# Altium schematic sheet SENSE.SchDoc
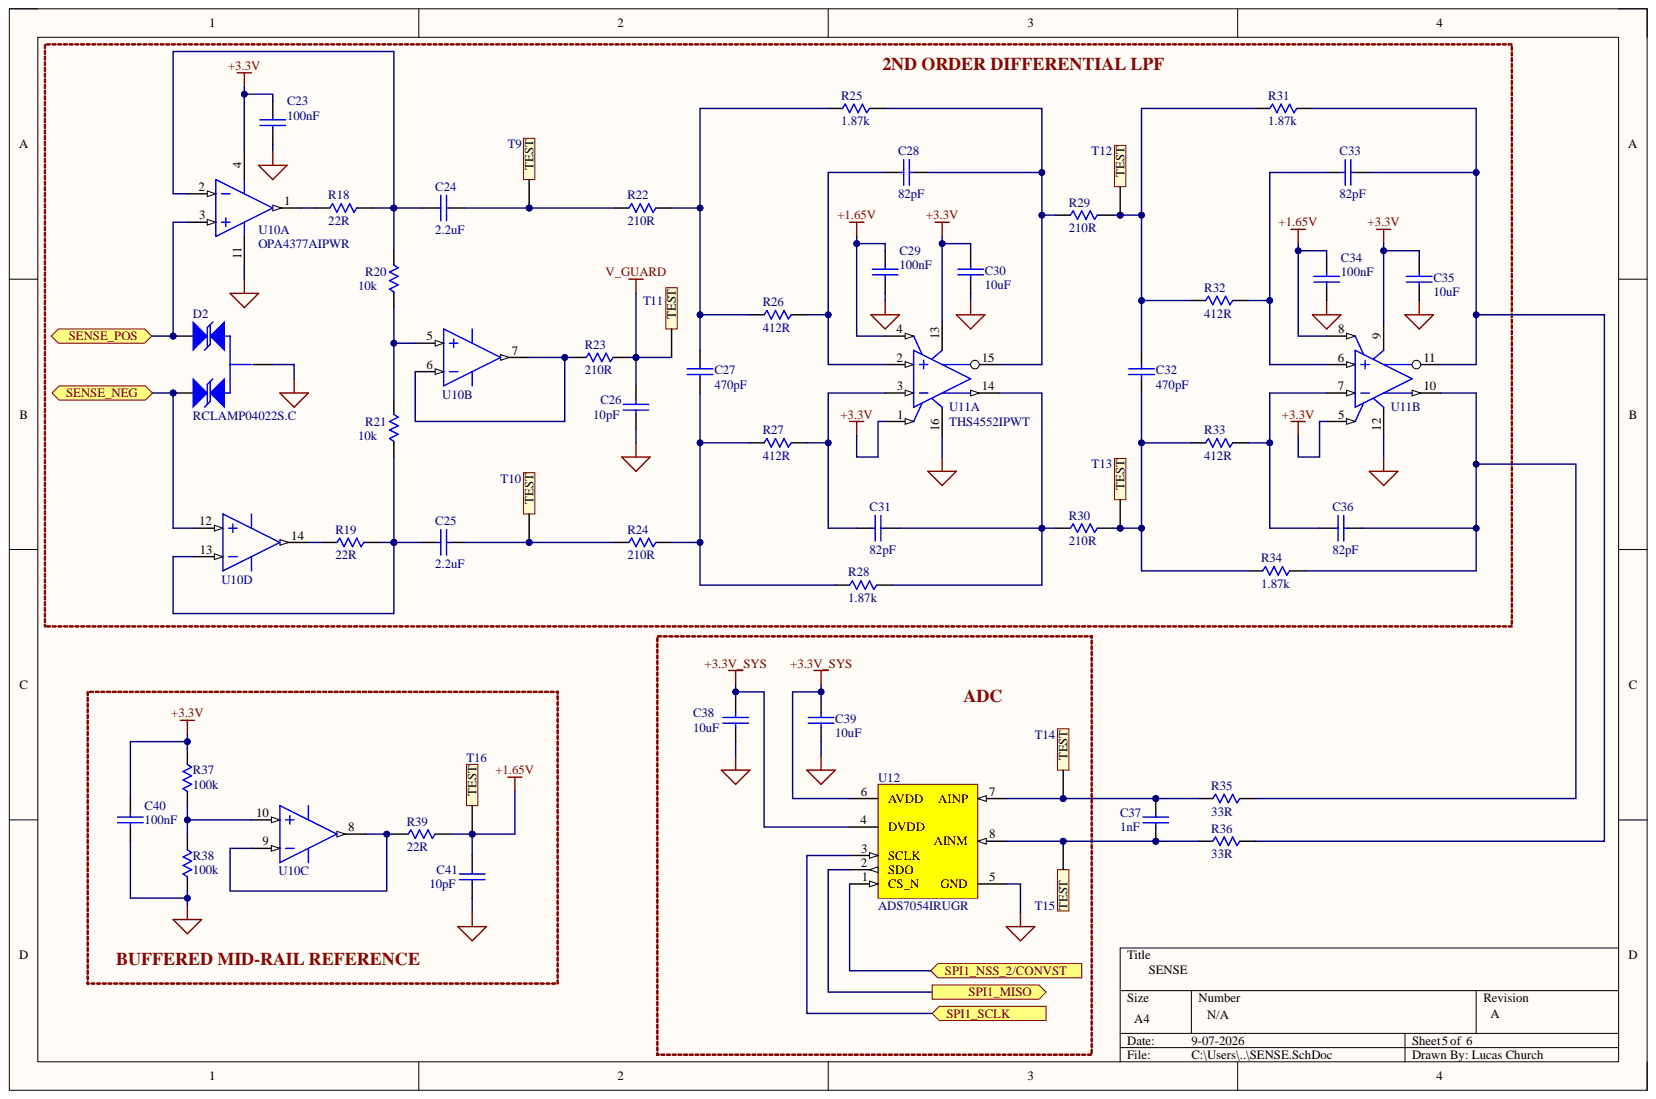
[diagram p0: SENSE.SchDoc]

## Parts
C23  100nF  [0603-CAP]
C24  2.2uF  [0603-CAP]
C25  2.2uF  [0603-CAP]
C26  10pF  [0603-CAP]
C27  470pF  [0603-CAP]
C28  82pF  [0603-CAP]
C29  100nF  [0603-CAP]
C30  10uF  [0603-CAP]
C31  82pF  [0603-CAP]
C32  470pF  [0603-CAP]
C33  82pF  [0603-CAP]
C34  100nF  [0603-CAP]
C35  10uF  [0603-CAP]
C36  82pF  [0603-CAP]
C37  1nF  [0603-CAP]
C38  10uF  [0603-CAP]
C39  10uF  [0603-CAP]
C40  100nF  [0603-CAP]
C41  10pF  [0603-CAP]
D2  RCLAMP04022S.C  [SOT-23 3L]
R18  22R  [0603-RES]
R19  22R  [0603-RES]
R20  10k  [0603-RES]
R21  10k  [0603-RES]
R22  210R  [0603-RES]
R23  210R  [0603-RES]
R24  210R  [0603-RES]
R25  1.87k  [0603-RES]
R26  412R  [0603-RES]
R27  412R  [0603-RES]
R28  1.87k  [0603-RES]
R29  210R  [0603-RES]
R30  210R  [0603-RES]
R31  1.87k  [0603-RES]
R32  412R  [0603-RES]
R33  412R  [0603-RES]
R34  1.87k  [0603-RES]
R35  33R  [0603-RES]
R36  33R  [0603-RES]
R37  100k  [0603-RES]
R38  100k  [0603-RES]
R39  22R  [0603-RES]
T9  5002K  [TESTPOINT White]  (value not drawn)
T10  5002K  [TESTPOINT White]  (value not drawn)
T11  5002K  [TESTPOINT White]  (value not drawn)
T12  5002K  [TESTPOINT White]  (value not drawn)
T13  5002K  [TESTPOINT White]  (value not drawn)
T14  5002K  [TESTPOINT White]  (value not drawn)
T15  5002K  [TESTPOINT White]  (value not drawn)
T16  5002K  [TESTPOINT White]  (value not drawn)
U10  OPA4377AIPWR  [PW0014A_N]  (parts A,B,C,D)
U11  THS4552IPWT  [PW0016A_N]  (parts A,B)
U12  ADS7054IRUGR  [8-X2QFN (1.5x1.5)]

## Ports
SENSE_NEG, SENSE_POS, SPI1_MISO, SPI1_NSS_2/CONVST, SPI1_SCLK

## Nets
+1.65V: C29.1 C34.1 C41.1 R39.2 T16.1 U11.4 U11.8
+3.3V: C23.1 C30.1 C35.1 C40.1 R37.2 U10.4 U11.1 U11.5 U11.9 U11.13
+3.3V_SYS: C38.1 C39.1 U12.4(DVDD) U12.6(AVDD)
GND: C23.2 C26.2 C29.2 C30.2 C34.2 C35.2 C38.2 C39.2 C40.2 C41.2 D2.3 R38.1 U10.11 U11.12 U11.16 U12.5(GND)
V_GUARD: C26.1 R23.2 T11.1
SENSE_NEG: D2.2 U10.12 ; port SENSE_NEG
SENSE_POS: D2.1 U10.3 ; port SENSE_POS
SPI1_MISO: U12.2(SDO) ; port SPI1_MISO
SPI1_NSS_2/CONVST: U12.1(CS_N) ; port SPI1_NSS_2/CONVST
SPI1_SCLK: U12.3(SCLK) ; port SPI1_SCLK
NetC24_1: C24.1 R22.1 T9.1
NetC24_2: C24.2 R18.2 R20.1 U10.2
NetC25_1: C25.1 R24.1 T10.1
NetC25_2: C25.2 R19.2 R21.2 U10.13
NetC27_1: C27.1 R24.2 R27.1 R28.1
NetC27_2: C27.2 R22.2 R25.1 R26.1
NetC28_1: C28.1 R26.2 U11.2
NetC28_2: C28.2 R25.2 R29.1 U11.15
NetC31_1: C31.1 R27.2 U11.3
NetC31_2: C31.2 R28.2 R30.1 U11.14
NetC32_1: C32.1 R30.2 R33.1 R34.1 T13.1
NetC32_2: C32.2 R29.2 R31.1 R32.1 T12.1
NetC33_1: C33.1 R32.2 U11.6
NetC33_2: C33.2 R31.2 R36.2 U11.11
NetC36_1: C36.1 R33.2 U11.7
NetC36_2: C36.2 R34.2 R35.2 U11.10
NetC37_1: C37.1 R35.1 T14.1 U12.7(AINP)
NetC37_2: C37.2 R36.1 T15.1 U12.8(AINM)
NetR18_1: R18.1 U10.1
NetR19_1: R19.1 U10.14
NetR20_2: R20.2 R21.1 U10.5
NetR23_1: R23.1 U10.6 U10.7
NetR37_1: R37.1 R38.2 U10.10
NetR39_1: R39.1 U10.8 U10.9

## Library data of the parts
C23 C29 C34 C40 = CGA3E2C0G1H472J080AA: CAP CER 100 NF 50V X7R 0603; Manufacturer 1: YAGEO; Manufacturer Part Number 1: CC0603KRX7R9BB104; Database Table Name: Ceramic 0603$; Tech#: SMT; Component Kind: Standard; Detailed Description: CAP CER 100 NF 50V X7R 0603; Price(100): 0.1434; Price(250): 0.10756; Supplier 1: DigiKey; Supplier 2: Mouser; Supplier Part Number 1: 311-1344-1-ND; Supplier Part Number 2: 810-CGA3E2C0G1H472J; Library Name: SGL_Capacitors.DBLib
C24 C25 = CGA3E2C0G1H472J080AA: CAP CER 2.2UF 35V X5R 0603; Manufacturer 1: Murata Electronics; Manufacturer Part Number 1: GRM188R6YA225MA12D; Database Table Name: Ceramic 0603$; Tech#: SMT; Component Kind: Standard; Detailed Description: CAP CER 2.2UF 35V X5R 0603; Price(100): 0.1434; Price(250): 0.10756; Supplier 1: DigiKey; Supplier 2: Mouser; Supplier Part Number 1: 490-10486-1-ND; Supplier Part Number 2: 810-CGA3E2C0G1H472J; Library Name: SGL_Capacitors.DBLib
C26 C41 = CGA3E2C0G1H472J080AA: CAP CER 10PF 50V C0G/NPO 0603; Manufacturer 1: YAGEO; Manufacturer Part Number 1: CC0603JRNPO9BN100; Database Table Name: Ceramic 0603$; Tech#: SMT; Component Kind: Standard; Detailed Description: CAP CER 10PF 50V C0G/NPO 0603; Price(100): 0.1434; Price(250): 0.10756; Supplier 1: DigiKey; Supplier 2: Mouser; Supplier Part Number 1: 311-1058-1-ND; Supplier Part Number 2: 810-CGA3E2C0G1H472J; Library Name: SGL_Capacitors.DBLib
C27 C32 = CGA3E2C0G1H472J080AA: CAP CER 470PF 50V C0G 0603; Manufacturer 1: Samsung; Manufacturer Part Number 1: CL10C471JB8NNNC; Database Table Name: Ceramic 0603$; Tech#: SMT; Component Kind: Standard; Detailed Description: CAP CER 470PF 50V C0G 0603; Price(100): 0.1434; Price(250): 0.10756; Supplier 1: DigiKey; Supplier 2: Mouser; Supplier Part Number 1: 1276-1168-1-ND; Supplier Part Number 2: 810-CGA3E2C0G1H472J; Library Name: SGL_Capacitors.DBLib
C28 C31 C33 C36 = CGA3E2C0G1H472J080AA: CAP CER 82PF 50V C0G 0603; Manufacturer 1: KEMET; Manufacturer Part Number 1: C0603C820J5GACTU; Database Table Name: Ceramic 0603$; Tech#: SMT; Component Kind: Standard; Detailed Description: CAP CER 82PF 50V C0G 0603; Price(100): 0.1434; Price(250): 0.10756; Supplier 1: DigiKey; Supplier 2: Mouser; Supplier Part Number 1: 399-C0603C820J5GACTUCT-ND; Supplier Part Number 2: 810-CGA3E2C0G1H472J; Library Name: SGL_Capacitors.DBLib
C30 C35 C38 C39 = CGA3E2C0G1H472J080AA: CAP CER 10uF 6.3V X5R 0603; Manufacturer 1: Murata Electronics; Manufacturer Part Number 1: GRM188Z71A106KA73D; Database Table Name: Ceramic 0603$; Detailed Description: CAP CER 100 NF 50V X7R 0603; Tech#: SMT; Component Kind: Standard; Price(100): 0.1434; Price(250): 0.10756; Supplier 1: DigiKey; Supplier 2: Mouser; Supplier Part Number 1: 490-GRM188Z71A106KA73DCT-ND; Supplier Part Number 2: 810-CGA3E2C0G1H472J; Library Name: SGL_Capacitors.DBLib
C37 = CGA3E2C0G1H472J080AA: CAP CER 1000 PF 50V C0G 0603; Manufacturer 1: Murata; Manufacturer Part Number 1: GRM1885C1H102JA01D; Database Table Name: Ceramic 0603$; Detailed Description: CAP CER 100 NF 50V X7R 0603; Tech#: SMT; Component Kind: Standard; Price(100): 0.1434; Price(250): 0.10756; Supplier 1: DigiKey; Supplier 2: Mouser; Supplier Part Number 1: 490-1451-1-ND; Supplier Part Number 2: 810-CGA3E2C0G1H472J; Library Name: SGL_Capacitors.DBLib
R18 R19 R39 = 4.99K 0603: RES SMD 22OHM 0.1% 1/10W 0603; Manufacturer 1: YAGEO; Manufacturer Part Number 1: RT0603BRD0722RL; Database Table Name: 0603$; Tech#: SMT; Component Kind: Standard; Detailed Description: RES SMD 22OHM 0.1% 1/10W 0603; Price(100): 0.023; Simulation: Resistor; Supplier 1: DigiKey; Supplier 2: Mouser; Supplier Part Number 1: 13-RT0603BRD0722RLCT-ND; Supplier Part Number 2: 754-RR0816P-4991D68H; Library Name: SGL_Resistives.DBLib
R20 R21 = 4.99K 0603: RES SMD 10K OHM 1% 1/10W 0603; Manufacturer 1: Panasonic Industry; Manufacturer Part Number 1: ERJ-3EKF1002V; Database Table Name: 0603$; Tech#: SMT; Component Kind: Standard; Detailed Description: RES SMD 10K OHM 1% 1/10W 0603; Price(100): 0.023; Simulation: Resistor; Supplier 1: DigiKey; Supplier 2: Mouser; Supplier Part Number 1: P10.0KHCT-ND; Supplier Part Number 2: 754-RR0816P-4991D68H; Library Name: SGL_Resistives.DBLib
R22-R24 R29 R30 = 4.99K 0603: RES SMD 210OHM 0.1% 1/10W 0603; Manufacturer 1: YAGEO; Manufacturer Part Number 1: RT0603BRD07210RL; Database Table Name: 0603$; Tech#: SMT; Component Kind: Standard; Detailed Description: RES SMD 210OHM 0.1% 1/10W 0603; Price(100): 0.023; Simulation: Resistor; Supplier 1: DigiKey; Supplier 2: Mouser; Supplier Part Number 1: YAG4502CT-ND; Supplier Part Number 2: 754-RR0816P-4991D68H; Library Name: SGL_Resistives.DBLib
R25 R28 R31 R34 = 4.99K 0603: RES SMD 1.87KOHM 0.1% 1/10W 0603; Manufacturer 1: YAGEO; Manufacturer Part Number 1: RT0603BRD071K87L; Database Table Name: 0603$; Tech#: SMT; Component Kind: Standard; Detailed Description: RES SMD 1.87KOHM 0.1% 1/10W 0603; Price(100): 0.023; Simulation: Resistor; Supplier 1: DigiKey; Supplier 2: Mouser; Supplier Part Number 1: YAG4497CT-ND; Supplier Part Number 2: 754-RR0816P-4991D68H; Library Name: SGL_Resistives.DBLib
R26 R27 R32 R33 = 4.99K 0603: RES SMD 412OHM 0.1% 1/10W 0603; Manufacturer 1: YAGEO; Manufacturer Part Number 1: RT0603BRD07412RL; Database Table Name: 0603$; Tech#: SMT; Component Kind: Standard; Detailed Description: RES SMD 412OHM 0.1% 1/10W 0603; Price(100): 0.023; Simulation: Resistor; Supplier 1: DigiKey; Supplier 2: Mouser; Supplier Part Number 1: YAG1659CT-ND; Supplier Part Number 2: 754-RR0816P-4991D68H; Library Name: SGL_Resistives.DBLib
R35 R36 = 4.99K 0603: RES SMD 33OHM 0.1% 1/10W 0603; Manufacturer 1: YAGEO; Manufacturer Part Number 1: RT0603BRD0733RL; Database Table Name: 0603$; Tech#: SMT; Component Kind: Standard; Detailed Description: RES SMD 33OHM 0.1% 1/10W 0603; Price(100): 0.023; Simulation: Resistor; Supplier 1: DigiKey; Supplier 2: Mouser; Supplier Part Number 1: 13-RT0603BRD0733RLCT-ND; Supplier Part Number 2: 754-RR0816P-4991D68H; Library Name: SGL_Resistives.DBLib
R37 R38 = 4.99K 0603: RES SMD 100KOHM 0.1% 1/10W 0603; Manufacturer 1: YAGEO; Manufacturer Part Number 1: RT0603BRD07100KL; Database Table Name: 0603$; Detailed Description: RES SMD 4.99KOHM 0.5% 1/16W 0603; Tech#: SMT; Component Kind: Standard; Price(100): 0.023; Simulation: Resistor; Supplier 1: DigiKey; Supplier 2: Mouser; Supplier Part Number 1: YAG1235CT-ND; Supplier Part Number 2: 754-RR0816P-4991D68H; Library Name: SGL_Resistives.DBLib
T9-T16 = 5002K: PC TEST POINT MINIATURE WHITE; Manufacturer 1: Keystone Electronics; Database Table Name: Testpoints$; Detailed Description: Connector, TestPoint White; Location: F1-33; Tech#: T/H; Component Kind: Standard; Manufacturer Part Number 1: 5002K; Price(1): 0.56; Price(10): 0.506; Price(100): 0.3541; Supplier 1: DigiKey; Supplier 2: Mouser; Supplier Part Number 1: 36-5002-ND; Supplier Part Number 2: 534-5002; Library Name: SGL_Connectors.DBLib
U10 = CMP-0272-01748-3: Low-Cost, Low Noise, 5.5 MHz CMOS Operational Amplifier, 2.2 to 5.5 V, -40 to 125 degC, 14-pin SOP (PW14), Green (RoHS & no Sb/Br); Manufacturer: Texas Instruments; CMRR(Min)(dB): 70; IIB(Max)(pA): 10; Iq per channel(Max)(mA): 1.05; Number of Channels: 4; Offset Drift(Typ)(uV/C): 0.32; Slew Rate(Typ)(V/us): 2; Sub Family: Low Input Bias Current Amp (<=10pA), Low Noise Amp (<=10nV/rtHz), Low Supply Voltage Amplifier (<=2.7V), Precision Amplifier; Total Supply Voltage(Max)(+5V is 5, +/-5V is 10): 5.5; Total Supply Voltage(Min)(+5V is 5, +/-5V is 10): 2.2; Vio (25C)(Max)(mV): 1; Vn at 1kHz(Typ)(nV/rtHz): 7.5; Code_JEDEC: MO-153; Component Kind: Standard; ComponentLink1Description: Manufacturer URL; ComponentLink1URL: http://www.ti.com/; ComponentLink2Description: Datasheet; ComponentLink2URL: http://www.ti.com/general/docs/lit/getliterature.tsp?genericPartNumber=OPA4377&fileType=pdf; ComponentLink3Description: Package Specification; ComponentLink3URL: http://www.ti.com/litv/pdf/mpds360; DatasheetVersion: SBOS504B; Eco-Plan: Green (RoHS & no Sb/Br); Library Name: Altium Content Vault; Mounting Technology: Surface Mount; PackageDescription: 14-Pin Plastic Small Outline, Body 5 x 4.4 mm, Pitch 0.65 mm; PackageReference: PW0014A; PackageVersion: 4040064-3/G, 02/2011; PartNumber: OPA4377AIPWR
U11 = THS4552IPWT: Dual Channel, Low-Noise, Precision, 150-MHz, Fully Differential Amplifier 16-TSSOP -40 to 125; Manufacturer_Name: Texas Instruments; Component Kind: Standard; Manufacturer_Part_Number: THS4552IPWT; RefDes: RefDes; TYPE: TYPE; Copyright: Copyright (C) 2025 Ultra Librarian. All rights reserved.; Library Name: BioZ.SchLib
U12 = ADS7054IRUGR: 14-Bit, 1MSPS, Differential Input, Small-Size Low-Power SAR ADC 8-X2QFN -40 to 125; Manufacturer_Name: Texas Instruments; Component Kind: Standard; Manufacturer_Part_Number: ADS7054IRUGR; RefDes: RefDes; TYPE: TYPE; Copyright: Copyright (C) 2026 Ultra Librarian. All rights reserved.; Library Name: BioZ.SchLib
D2 = RCLAMP04022S.C: Component Kind: Standard; Library Name: BioZ.SchLib
